# Altium schematic sheet 继电器模块.SchDoc
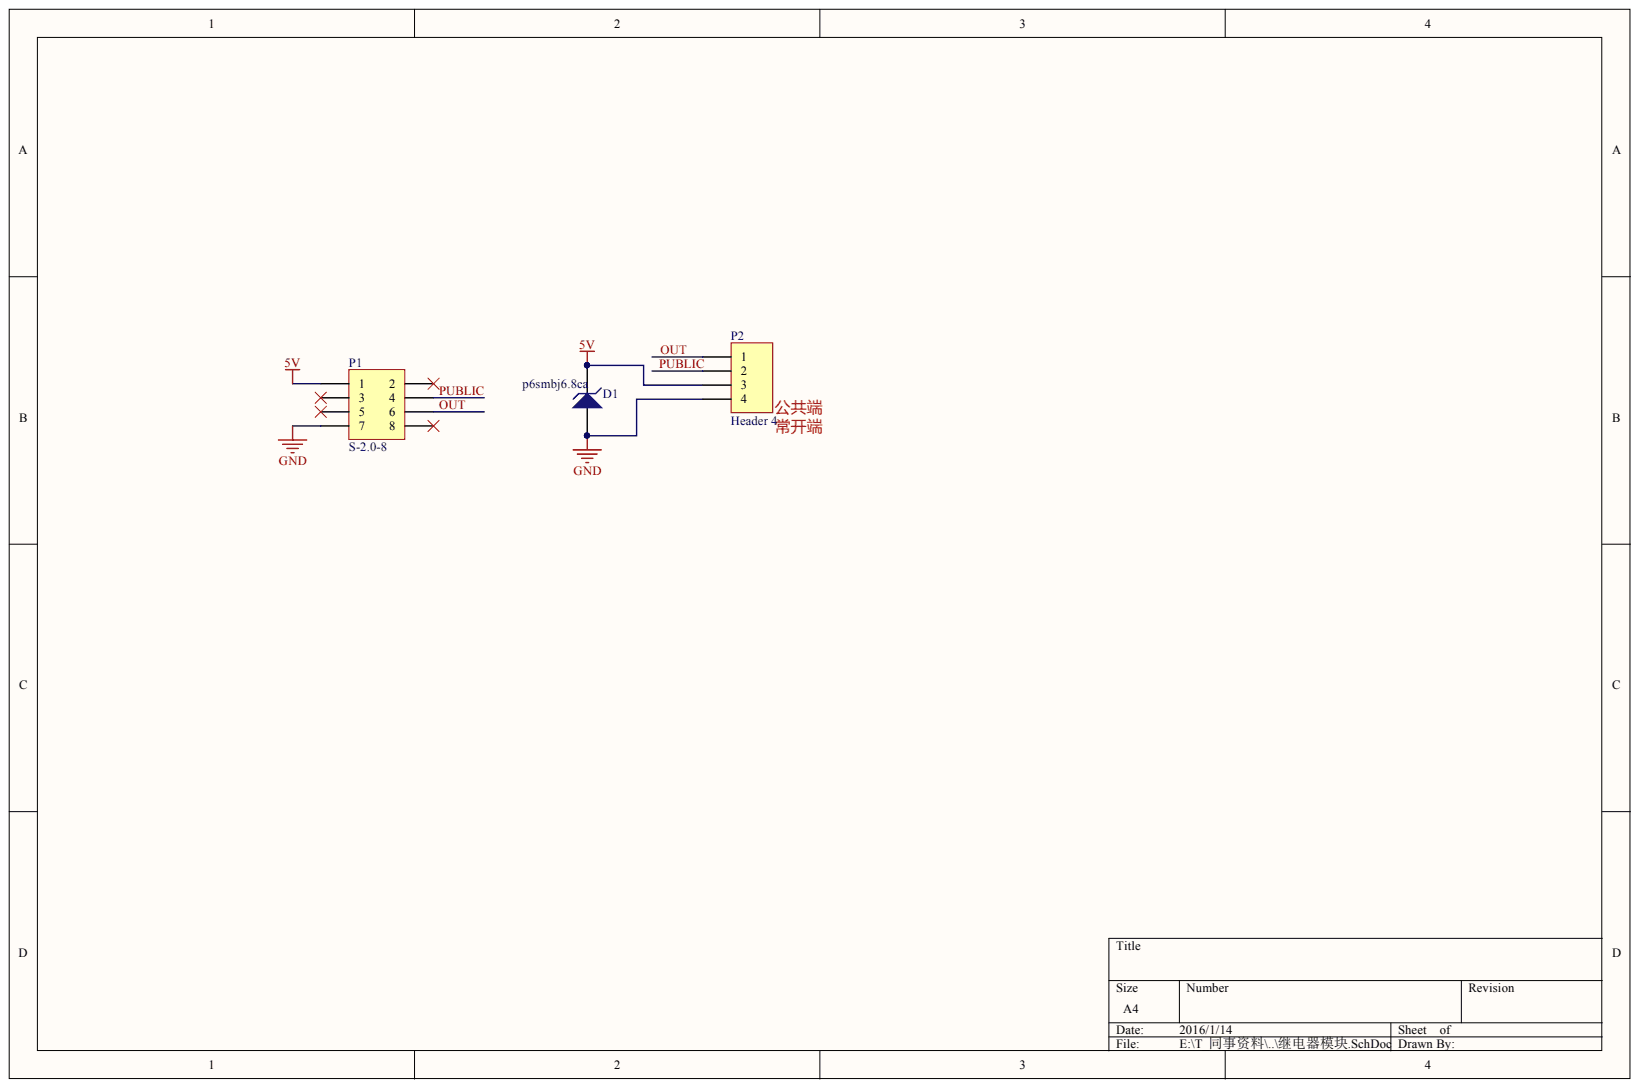
[diagram p0: 继电器模块.SchDoc]

## Parts
D1  p6smbj6.8ca  [SMB-2]
P1  S-2.0-8  [SHDR2X4]
P2  Header 4  [DG3.81-4P-S]

## Nets
5V: D1.2 P1.1 P2.3
GND: D1.1 P1.7 P2.4
OUT: P1.6 P2.1
PUBLIC: P1.4 P2.2

Not connected: P1 2 3 5 8

## Library data of the parts
D1 = D Zener: Zener Diode; Component Kind: Standard; LatestRevisionDate: 17-Jul-2002; LatestRevisionNote: Re-released for DXP Platform.; Library Name: Miscellaneous Devices.IntLib; PackageReference: DIODE-0.7; Published: 8-Jun-2000; Signal Integrity: D Zener; Simulation: ZENER; Publisher: Altium Limited
P1 = Header 4X2: Header, 4-Pin, Dual row; Component Kind: Standard; LatestRevisionDate: 17-Jul-2002; LatestRevisionNote: Re-released for DXP Platform.; Library Name: Miscellaneous Connectors.IntLib; Signal Integrity: Connector; Publisher: Altium Limited
P2 = Header 4: Header, 4-Pin; Component Kind: Standard; LatestRevisionDate: 17-Jul-2002; LatestRevisionNote: Re-released for DXP Platform.; Library Name: Miscellaneous Connectors.IntLib; Signal Integrity: Connector; Publisher: Altium Limited
